# Altium schematic sheet 电源.SchDoc(电源)
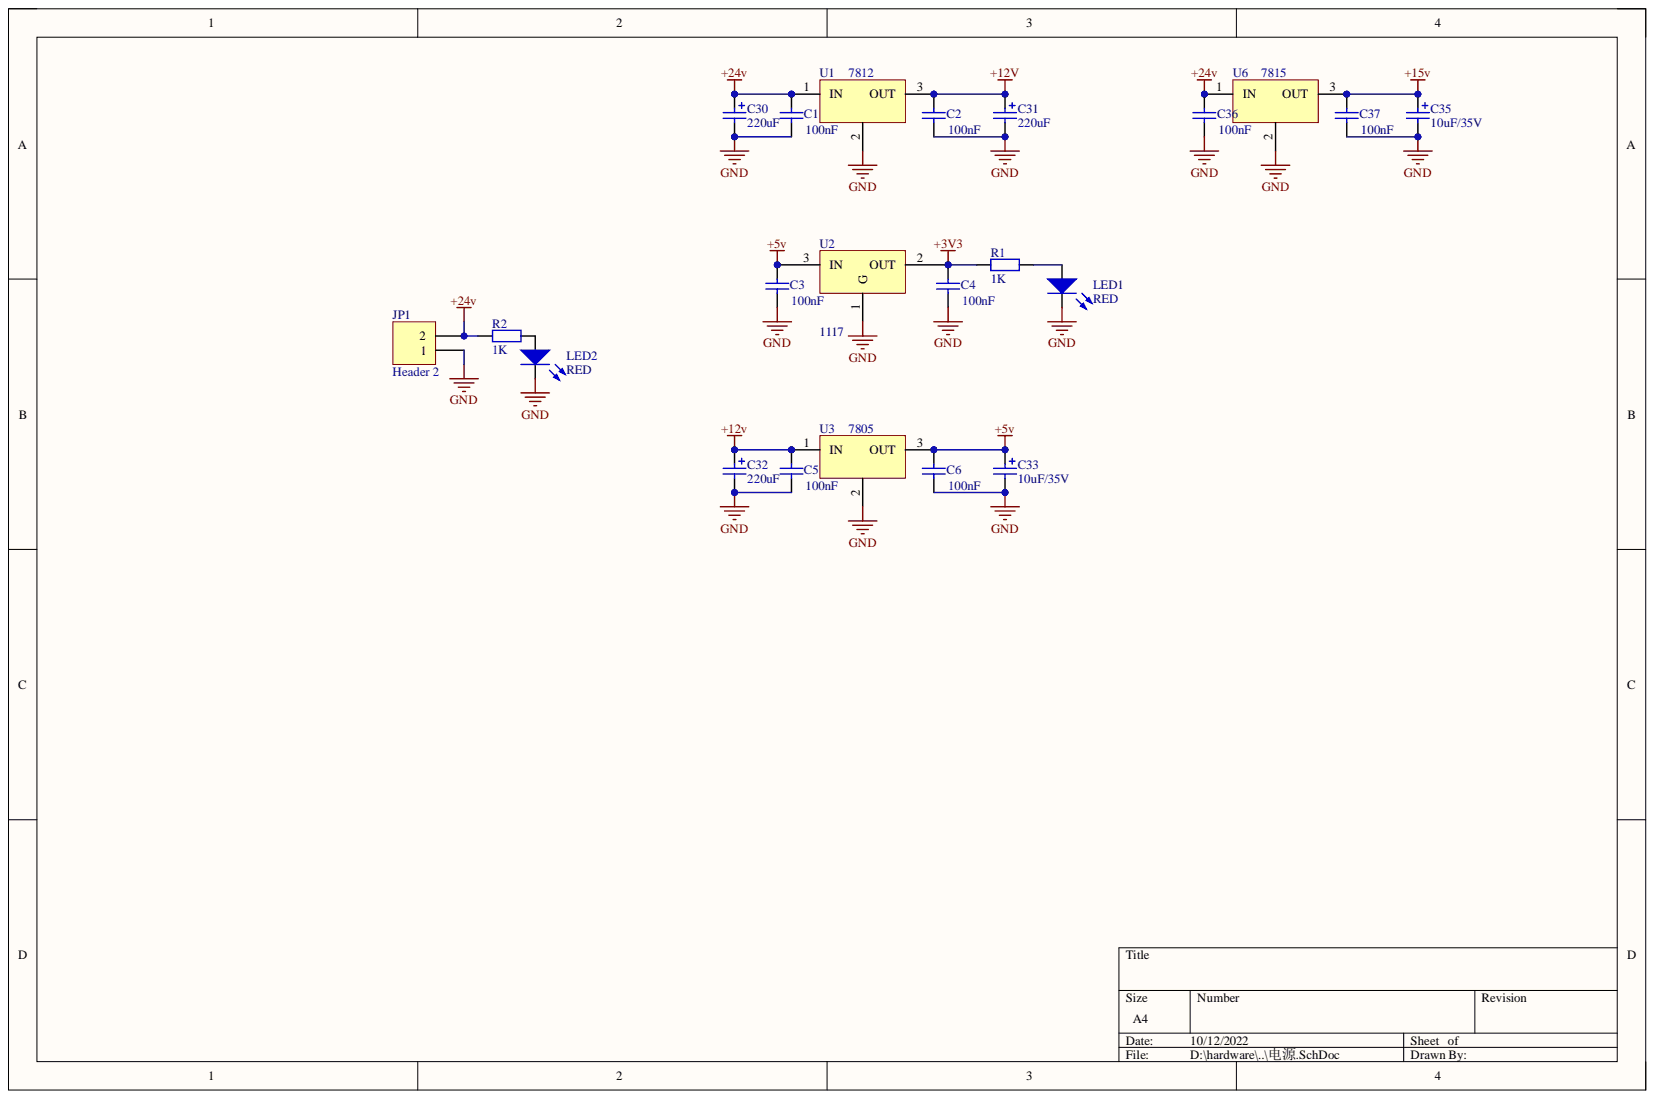
[diagram p0: 电源.SchDoc]

## Parts
C1  100nF  [0805c]
C2  100nF  [0805c]
C3  100nF  [0805c]
C4  100nF  [0805c]
C5  100nF  [0805c]
C6  100nF  [0805c]
C30  220uF  [CAP-SMD_BD8.0-L8.3-W8.3-FD]
C31  220uF  [CAP-SMD_BD8.0-L8.3-W8.3-FD]
C32  220uF  [CAP-SMD_BD8.0-L8.3-W8.3-FD]
C33  10uF/35V  [CAP-SMD_L6.0-W3.2-R-RD]
C35  10uF/35V  [CAP-SMD_L6.0-W3.2-R-RD]
C36  100nF  [0805c]
C37  100nF  [0805c]
JP1  Header 2  [KF2EDGK5.08-2p-弯针]
LED1  RED  [0805d_red]
LED2  RED  [0805d_red]
R1  1K  [0805r]
R2  1K  [0805r]
U1  7812  [TO263(D2PACK)]
U2  1117  [SOT223]
U3  7805  [TO252]
U6  7815  [TO263(D2PACK)]

## Nets
+3V3: C4.2 R1.1 U2.2
+5v: C3.2 C6.2 C33.1 U2.3 U3.3
+12v: C2.2 C5.2 C31.1 C32.1 U1.3 U3.1
+15v: C35.1 C37.2 U6.3
+24v: C1.2 C30.1 C36.2 JP1.2 R2.1 U1.1 U6.1
GND: C1.1 C2.1 C3.1 C4.1 C5.1 C6.1 C30.2 C31.2 C32.2 C33.2 C35.2 C36.1 C37.1 JP1.1 LED1.2 LED2.2 U1.2 U2.1 U3.2 U6.2
NetLED1_1: LED1.1 R1.2
NetLED2_1: LED2.1 R2.2

## Library data of the parts
C1-C6 C36 C37 = Cap: 无极性定值电容; Component Kind: Standard; LatestRevisionDate: 17-Jul-2002; LatestRevisionNote: Re-released for DXP Platform.; Library Name: 常用.SchLib; PackageDescription: Radial Cap, Thru-Hole; 2 Leads; 0.3 in Pin Spacing; PackageReference: RAD-0.3; Published: 8-Jun-2000; Signal Integrity: Cap; Simulation: CAP; Publisher: Altium Limited
C30-C32 = Cap Pol1: 有极性定值电容器; Component Kind: Standard; LatestRevisionDate: 17-Jul-2002; LatestRevisionNote: Re-released for DXP Platform.; Library Name: 常用.SchLib; PackageDescription: Polarized Capacitor (Radial); 2 Leads; PackageReference: RB7.6-15; Signal Integrity: Cap Pol; Simulation: CAP; Publisher: Altium Limited
C33 C35 = Cap tan: 钽电容; Component Kind: Standard; LatestRevisionDate: 17-Jul-2002; LatestRevisionNote: Re-released for DXP Platform.; Library Name: 常用.SchLib; PackageDescription: Polarized Capacitor (Radial); 2 Leads; PackageReference: RB7.6-15; Signal Integrity: Cap Pol; Simulation: CAP; Publisher: Altium Limited
JP1 = Header 2: Header, 2-Pin; Component Kind: Standard; LatestRevisionDate: 17-Jul-2002; LatestRevisionNote: Re-released for DXP Platform.; Signal Integrity: Connector; Library Name: 接插头.SchLib; Publisher: Altium Limited
LED1 LED2 = LED0: 普通LED; Component Kind: Standard; LatestRevisionDate: 08-Jul-2005; LatestRevisionNote: Mech Layer 1 removed.; Library Name: 常用.SchLib; PackageDescription: LED; 2 Leads; PackageReference: LED-0; Simulation: LED0; Value: RED; Publisher: Altium Limited
R1 R2 = Res2: 固定电阻器; Component Kind: Standard; LatestRevisionDate: 17-Jul-2002; LatestRevisionNote: Re-released for DXP Platform.; Library Name: 常用.SchLib; PackageDescription: Axial Device, Thru-Hole; 2 Leads; 0.4 in Pin Spacing; PackageReference: AXIAL-0.4; Published: 8-Jun-2000; Signal Integrity: Res; Simulation: RESISTOR; Publisher: Altium Limited
U1 U3 U6 = 78XX: 线性稳压5v输出; Component Kind: Standard; Library Name: 电源芯片.SchLib
U2 = AMS1117: Component Kind: Standard; Library Name: 电源芯片.SchLib
